# Altium schematic sheet Sensor_Box_GPRS.SchDoc
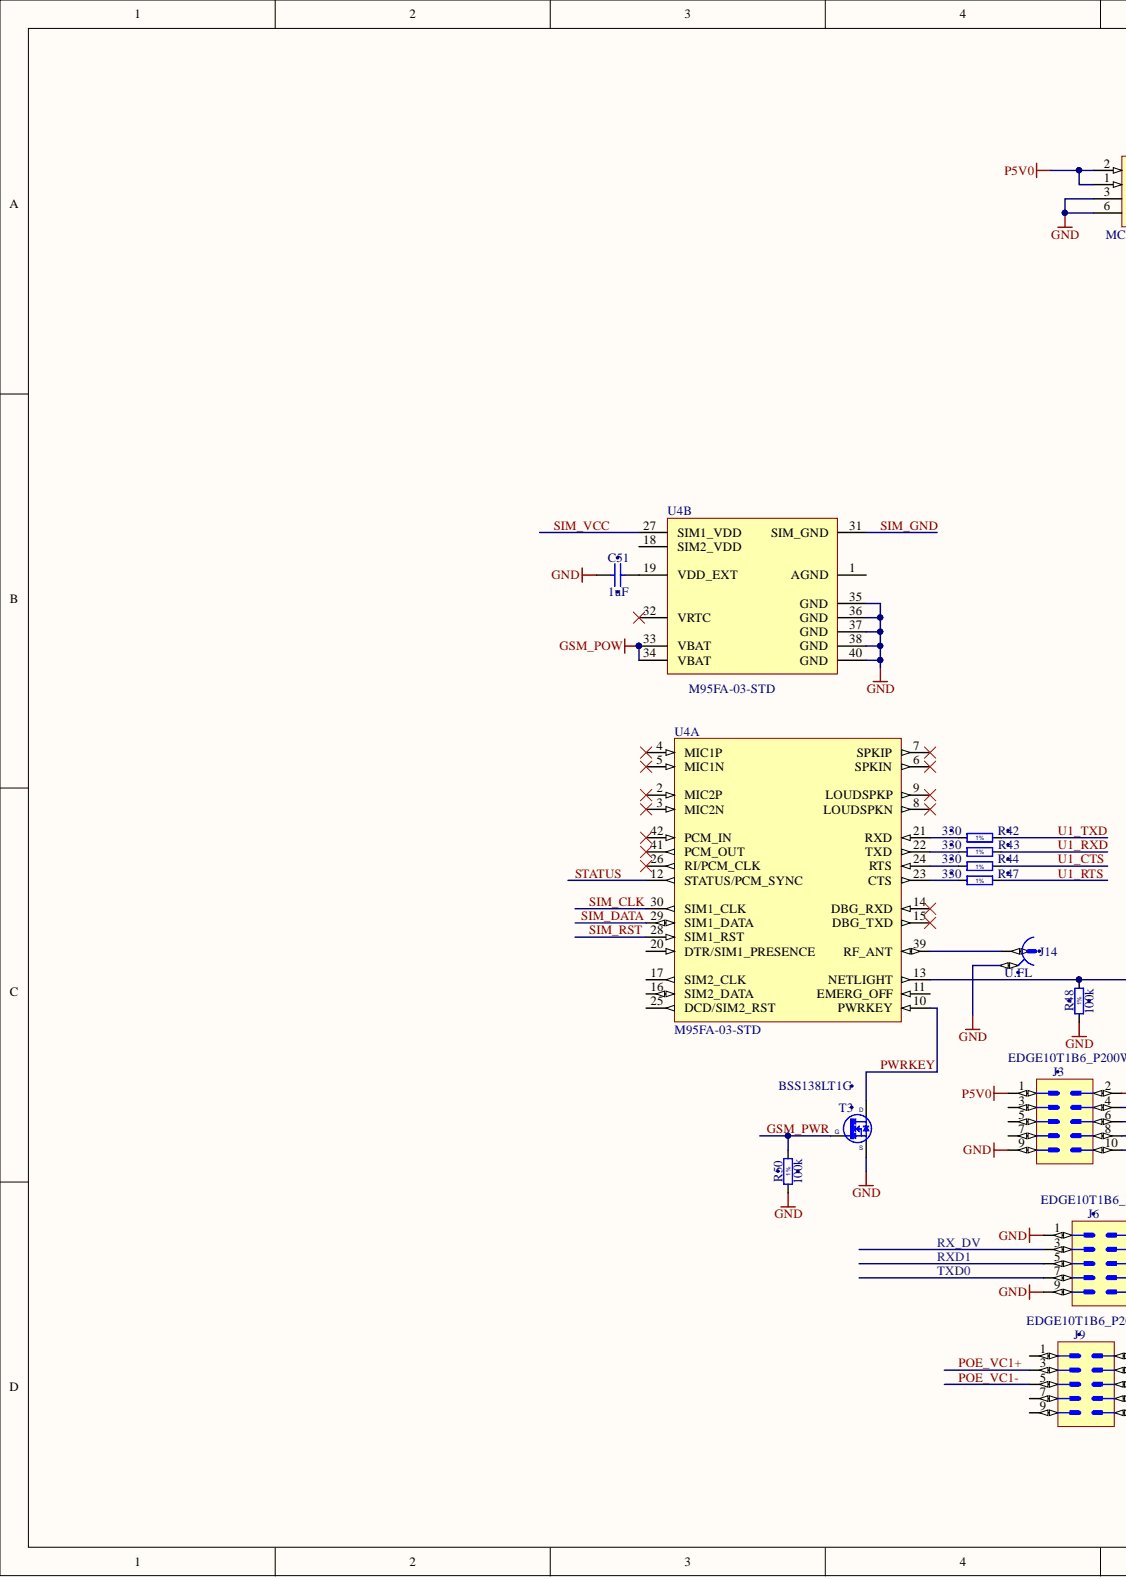
[diagram p0: Sensor_Box_GPRS.SchDoc, left]
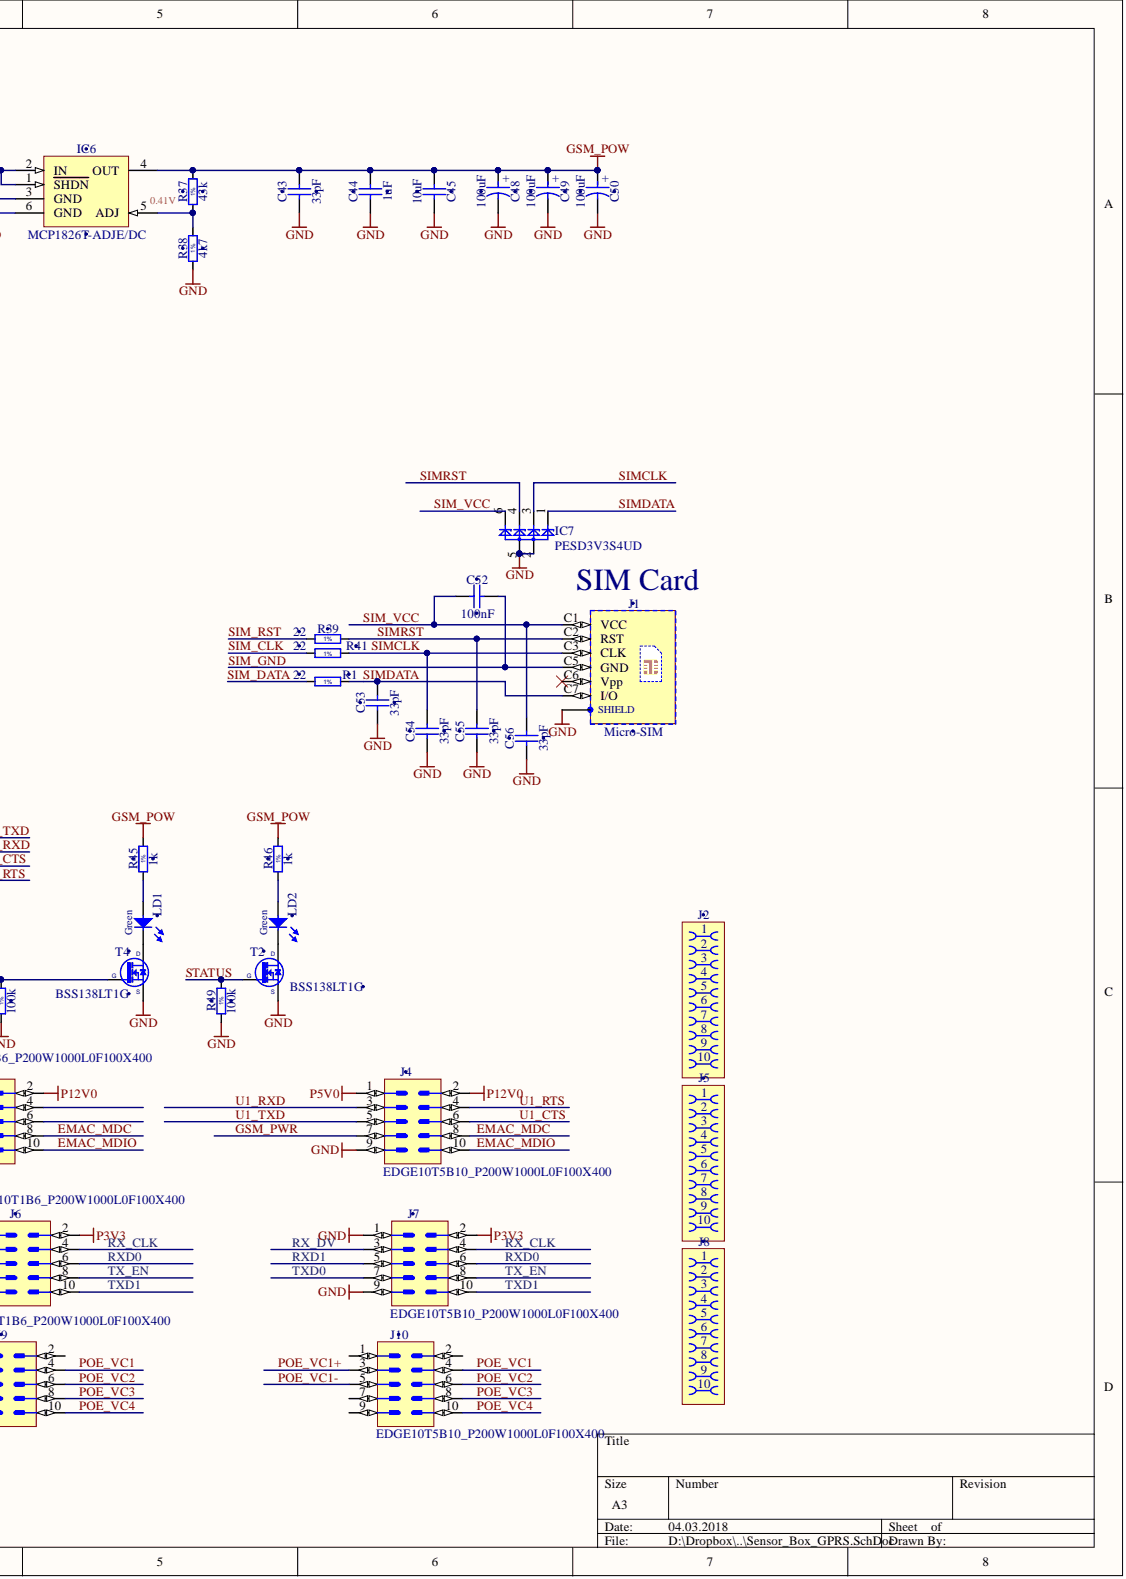
[diagram p1: Sensor_Box_GPRS.SchDoc, right]

## Parts
C43  33pF  [CAPC1005X55N]
C44  1uF  [CAPC1005X55N]
C45  10uF  [CAPC2012X135N]
C48  100uF  [CAPAE660X540N]
C49  100uF  [CAPAE660X540N]
C50  100uF  [CAPAE660X540N]
C51  1uF  [CAPC1005X55N]
C52  100nF  [CAPC1005X55N]
C53  33pF  [CAPC1005X55N]
C54  33pF  [CAPC1005X55N]
C55  33pF  [CAPC1005X55N]
C56  33pF  [CAPC1005X55N]
IC6  MCP1826T-ADJE/DC  [SOT127P700X180-6N]
IC7  PESD3V3S4UD  [SOT457]
J1  Micro-SIM  [MOLEX_78800-0001]
J2  Family  [AVX_00 9159 010 061 916]  (value not drawn)
J3  Family  [EDGE10T1B6_P200W1000L0F100X400]  (value not drawn)  (no BOM)
J4  Family  [EDGE10T5B10_P200W1000L0F100X400]  (value not drawn)  (no BOM)
J5  Family  [AVX_00 9159 010 061 916]  (value not drawn)
J6  Family  [EDGE10T1B6_P200W1000L0F100X400]  (value not drawn)  (no BOM)
J7  Family  [EDGE10T5B10_P200W1000L0F100X400]  (value not drawn)  (no BOM)
J8  Family  [AVX_00 9159 010 061 916]  (value not drawn)
J9  Family  [EDGE10T1B6_P200W1000L0F100X400]  (value not drawn)  (no BOM)
J10  Family  [EDGE10T5B10_P200W1000L0F100X400]  (value not drawn)  (no BOM)
J14  U.FL  [HIROSE_U.FL-R-SMT-1(10)]
LD1  Green  [LED_KINGBRIGHT_KPTR3216]
LD2  Green  [LED_KINGBRIGHT_KPTR3216]
R1  22  [RESC1005X40N]
R37  43k  [RESC1608X55N]
R38  4k7  [RESC1005X40N]
R39  22  [RESC1005X40N]
R41  22  [RESC1005X40N]
R42  330  [RESC1005X40N]
R43  330  [RESC1005X40N]
R44  330  [RESC1005X40N]
R45  1k  [RESC1005X40N]
R46  1k  [RESC1005X40N]
R47  330  [RESC1005X40N]
R48  100k  [RESC1005X40N]
R49  100k  [RESC1005X40N]
R50  100k  [RESC1005X40N]
T2  BSS138LT1G  [SOT95P240X110-3N]
T3  BSS138LT1G  [SOT95P240X110-3N]
T4  BSS138LT1G  [SOT95P240X110-3N]
U4  M95FA-03-STD  [QUEC-M95FA-03-STD_V]  (parts A,B)

## Nets
GND: C43.1 C44.1 C45.2 C48.2 C49.2 C50.2 C51.1 C53.1 C54.1 C55.1 C56.1 IC6.3(GND) IC6.6(GND) IC7.2 IC7.5 J1.SHIELD J3.9 J4.9 J6.1 J6.9 J7.1 J7.9 J14.2 R38.1 R48.1 R49.1 R50.1 T2.2 T3.2 T4.2 U4.35(GND) U4.36(GND) U4.37(GND) U4.38(GND) U4.40(GND)
GSM_POW: C43.2 C44.2 C45.1 C48.1 C49.1 C50.1 IC6.4(OUT) R37.2 R45.2 R46.2 U4.33(VBAT) U4.34(VBAT)
P3V3: J6.2 J7.2
P5V0: IC6.1(SHDN) IC6.2(IN) J3.1 J4.1
P12V0: J3.2 J4.2
EMAC_MDC: J3.8 J4.8
EMAC_MDIO: J3.10 J4.10
GSM_PWR: J4.7 R50.2 T3.1
POE_VC1: J9.4 J10.4
POE_VC1+: J9.3 J10.3
POE_VC1-: J9.5 J10.5
POE_VC2: J9.6 J10.6
POE_VC3: J9.8 J10.8
POE_VC4: J9.10 J10.10
PWRKEY: T3.3 U4.10(PWRKEY)
RX_CLK: J6.4 J7.4
RX_DV: J6.3 J7.3
RXD0: J6.6 J7.6
RXD1: J6.5 J7.5
SIM_CLK: R41.1 U4.30(SIM1_CLK)
SIM_DATA: R1.1 U4.29(SIM1_DATA)
SIM_GND: C52.2 J1.C5(GND) U4.31(SIM_GND)
SIM_RST: R39.1 U4.28(SIM1_RST)
SIM_VCC: C52.1 C56.2 IC7.6 J1.C1(VCC) U4.27(SIM1_VDD)
SIMCLK: C54.2 IC7.3 J1.C3(CLK) R41.2
SIMDATA: C53.2 IC7.1 J1.C7(I/O) R1.2
SIMRST: C55.2 IC7.4 J1.C2(RST) R39.2
STATUS: R49.2 T2.1 U4.12(STATUS/PCM_SYNC)
TX_EN: J6.8 J7.8
TXD0: J6.7 J7.7
TXD1: J6.10 J7.10
U1_CTS: J4.6 R44.2
U1_RTS: J4.4 R47.2
U1_RXD: J4.3 R43.2
U1_TXD: J4.5 R42.2
NetC51_2: C51.2 U4.19(VDD_EXT)
NetIC6_5: IC6.5(ADJ) R37.1 R38.2
NetJ14_1: J14.1 U4.39(RF_ANT)
NetLD1_1: LD1.1 T4.3
NetLD1_2: LD1.2 R45.1
NetLD2_1: LD2.1 T2.3
NetLD2_2: LD2.2 R46.1
NetR42_1: R42.1 U4.21(RXD)
NetR43_1: R43.1 U4.22(TXD)
NetR44_1: R44.1 U4.24(RTS)
NetR47_1: R47.1 U4.23(CTS)
NetR48_2: R48.2 T4.1 U4.13(NETLIGHT)

Not connected: J1 C6(Vpp); J3 3 4 5 6 7; J9 1 2 7 9; J10 1 2 7 9; U4 1(AGND) 2(MIC2P) 3(MIC2N) 4(MIC1P) 5(MIC1N) 6(SPKIN) 7(SPKIP) 8(LOUDSPKN) 9(LOUDSPKP) 11(EMERG_OFF) 14(DBG_RXD) 15(DBG_TXD) 16(SIM2_DATA) 17(SIM2_CLK) 18(SIM2_VDD) 20(DTR/SIM1_PRESENCE) 25(DCD/SIM2_RST) 26(RI/PCM_CLK) 32(VRTC) 41(PCM_OUT) 42(PCM_IN)

## Library data of the parts
C43 C53-C56 = CC0402_33PF_50V_5%_C0G: Non-polarised capacitor; Manufacturer: GENERIC; Manufacturer1 Part Number: C0402C330J5GACTU; Part Description: SMD Multilayer Chip Ceramic Capacitor; Database Table Name: Capacitors SMD; TC: C0G/NP0; Tolerance: ±5%; Voltage: 50V; Case: 0402; Component Kind: Standard; Component Type: Standard; CreateDate: 03.12.2007; HelpURL: \\cern.ch\dfs\Applications\Altium\Datasheets\CC0805_C0G_KEMET_(Commercial Grade).pdf; LatestRevisionDate: 22.04.2015; Library Name: Capacitors.DbLib; Manufacturer1 ComponentHeight: 0.55mm; Manufacturer1 Example: KEMET; Manufacturer Part Number: CC0402_33PF_50V_5%_C0G; Mounted: Yes; Part Number: CC0402_33PF_50V_5%_C0G; Pin Count: 2; Sense: No; SMD: Yes; Socket: No; Status: Preferred; Value: 33pF; Author: CERN DEM JLC
C44 C51 = CC0402_1UF_16V_10%_X5R: Non-polarised capacitor; Manufacturer: GENERIC; Manufacturer1 Part Number: C1005X5R1C105KT; Part Description: SMD Multilayer Chip Ceramic Capacitor; Database Table Name: Capacitors SMD; TC: X5R; Tolerance: ±10%; Voltage: 16V; Case: 0402; Component Kind: Standard; Component Type: Standard; CreateDate: 25.10.2012; HelpURL: \\cern.ch\dfs\Applications\Altium\Datasheets\CC0402_X5R_TDK_C.pdf; LatestRevisionDate: 25.10.2012; Library Name: Capacitors.DbLib; Manufacturer1 ComponentHeight: 0.55mm; Manufacturer1 Example: TDK; Manufacturer Part Number: CC0402_1UF_16V_10%_X5R; Mounted: Yes; Part Number: CC0402_1UF_16V_10%_X5R; Pin Count: 2; Sense: No; SMD: Yes; Socket: No; Status: Preferred; Value: 1uF; Author: CERN DEM JLC
C45 = CC0805_10UF_16V_10%_X5R: Non-polarised capacitor; Manufacturer: GENERIC; Manufacturer1 Part Number: C0805C106K4PAC; Part Description: SMD Multilayer Chip Ceramic Capacitor; Database Table Name: Capacitors SMD; TC: X5R; Tolerance: ±10%; Voltage: 16V; Case: 0805; Component Kind: Standard; Component Type: Standard; CreateDate: 03.12.2007; HelpURL: \\cern.ch\dfs\Applications\Altium\Datasheets\CC1206_X5R_KEMET.pdf; LatestRevisionDate: 03.12.2007; Library Name: Capacitors.DbLib; Manufacturer1 ComponentHeight: 1.4mm; Manufacturer1 Example: KEMET; Manufacturer Part Number: CC0805_10UF_16V_10%_X5R; Mounted: Yes; Part Number: CC0805_10UF_16V_10%_X5R; Pin Count: 2; Sense: No; SMD: Yes; Socket: No; Status: Preferred; Value: 10uF; Author: CERN DEM JLC
C48-C50 = CAE660-540_100UF_16V_20%: Polarised capacitor; Manufacturer: PANASONIC; Manufacturer Part Number: EEEFK1C101AP; Part Description: Aluminum Electrolytic SMT Capacitor; Database Table Name: Capacitors SMD; Tolerance: ±20%; Voltage: 16V; Case: D; ComponentHeight: 5.8mm; Component Kind: Standard; Component Type: Standard; CreateDate: 03.12.2007; HelpURL: \\cern.ch\dfs\Applications\Altium\Datasheets\CAE_PANASONIC_FK.pdf; LatestRevisionDate: 05.11.2014; Library Name: Capacitors.DbLib; Mounted: Yes; Part Number: CAE660-540_100UF_16V_20%; Pin Count: 2; Sense: No; SMD: Yes; Socket: No; Status: Not Recommended; Value: 100uF; Author: CERN DEM JLC
C52 = CC0402_100NF_16V_10%_X7R: Non-polarised capacitor; Manufacturer: GENERIC; Manufacturer1 Part Number: GRM155R71C104KA88D; Part Description: SMD Multilayer Chip Ceramic Capacitor; Database Table Name: Capacitors SMD; TC: X7R; Tolerance: ±10%; Voltage: 16V; Case: 0402; Component Kind: Standard; Component Type: Standard; CreateDate: 05.12.2013; HelpURL: \\cern.ch\dfs\Applications\Altium\Datasheets\CC0402_X7R_MURATA_GRM.pdf; LatestRevisionDate: 03.12.2007; Library Name: Capacitors.DbLib; Manufacturer1 ComponentHeight: 0.55mm; Manufacturer1 Example: MURATA; Manufacturer Part Number: CC0402_100NF_16V_10%_X7R; Mounted: Yes; Part Number: CC0402_100NF_16V_10%_X7R; Pin Count: 2; Sense: No; SMD: Yes; Socket: No; Status: Not Recommended; Value: 100nF; Author: CERN DEM JLC
IC6 = MCP1826T-ADJE/DC: 0.8V to 5.0V, 1A Low Voltage, Low Quiescent Current, Adjustable Positive LDO Regulator; Manufacturer: MICROCHIP TECHNOLOGY; Bonding: No; Database Table Name: Regulators; PressFit: No; Case: SOT223; ComponentHeight: 1.8mm; Component Kind: Standard; Component Type: Standard; CreateDate: 03.10.2012; Device: MCP1826T-ADJE/DC; HelpURL: \\cern.ch\dfs\Applications\Altium\Datasheets\MCP1826T-ADJE-DC.pdf; LatestRevisionDate: 03.10.2012; Library Name: ICs And Semiconductors.DbLib; Manufacturer Part Number: MCP1826T-ADJE/DC; Mounted: Yes; PackageDescription: SOT223, 6-Leads, Body 6.6x7.3mm (max), Pitch 1.27mm, IPC Medium Density; Part Description: 0.8V to 5.0V, 1A Low Voltage, Low Quiescent Current, Adjustable Positive LDO Regulator; Part Number: MCP1826T-ADJE/DC; Pin Count: 6; Sense: No; SMD: Yes; Socket: No; Author: CERN DEM JLC
IC7 = D_TVS_PESD3V3S4UD: 3.3V TVS; Component Kind: Standard; Library Name: LIBRARY_SCH.SCHLIB
J1 = MOLEX_78800-0001: 6 Contacts + Shield SIM Connector; Part Description: 1.50mm Height Hinged-style micro-SIM Card Socket Without Detect Switch, Surface Mount, 6 Circuits, Low-Halogen, Lead-Free; Database Table Name: MOLEX; PressFit: No; ComponentHeight: 1.6mm; Component Kind: Standard; Component Type: Standard; CreateDate: 30.01.2018; Family: Micro-SIM; HelpURL: \\cern.ch\dfs\Applications\Altium\Datasheets\MOLEX_78800-0001.pdf; LatestRevisionDate: 30.01.2018; Library Name: Connectors.DbLib; Manufacturer: MOLEX; Manufacturer Part Number: 78800-0001; Mounted: Yes; Part Number: MOLEX_78800-0001; Pin Count: 6; Sense: No; SMD: Yes; Socket: No; Status: None; Author: CERN DEM MR
J2 J5 J8 = AVX_00 9159 010 061 916: Open Ended Card Edge Connector, 9159 Series; Manufacturer: AVX; Part Description: 10 Contacts, 2mm Pitch, Open Ended Card Edge Connector, 9159 Series; Database Table Name: Miscellaneous; PressFit: No; ComponentHeight: 1.6mm; Component Kind: Standard; Component Type: Standard; CreateDate: 13.02.2018; HelpURL: \\cern.ch\dfs\Applications\Altium\Datasheets\AVX_00 9159 010 061 916.pdf; LatestRevisionDate: 13.02.2018; Library Name: Connectors.DbLib; Manufacturer Part Number: 00 9159 010 061 916; Mounted: Yes; Part Number: AVX_00 9159 010 061 916; Pin Count: 10; Sense: No; SMD: No; Socket: No; Status: None; Author: CERN DEM MR
J3 J6 J9 = EDGE10T1B6_P200W1000L0F100X400: PCB Edge Connector 10 (2x5); Part Description: 10 Contacts Board Edge Connector, Pitch 2mm, Length 0mm, Width 10mm, Finger Lenght 4mm, Finger Width 1mm; Database Table Name: Miscellaneous; Mounted: No; PressFit: No; ComponentHeight: 0mm; Component Type: Standard (No BOM); CreateDate: 14.02.2018; HelpURL: \\cern.ch\dfs\Applications\Altium\Datasheets\EDGE10T1B6_P200W1000L0F100X400.pdf; LatestRevisionDate: 14.02.2018; Library Name: Connectors.DbLib; Part Number: EDGE10T1B6_P200W1000L0F100X400; Pin Count: 10; Sense: No; SMD: No; Socket: No; Status: None; Author: CERN DEM MR
J4 J7 J10 = EDGE10T5B10_P200W1000L0F100X400: PCB Edge Connector 10 (2x5); Part Description: 10 Contacts Board Edge Connector, Pitch 2mm, Length 0mm, Width 10mm, Finger Lenght 4mm, Finger Width 1mm; Database Table Name: Miscellaneous; Mounted: No; PressFit: No; ComponentHeight: 0mm; Component Type: Standard (No BOM); CreateDate: 14.02.2018; HelpURL: \\cern.ch\dfs\Applications\Altium\Datasheets\EDGE10T5B10_P200W1000L0F100X400.pdf; LatestRevisionDate: 14.02.2018; Library Name: Connectors.DbLib; Part Number: EDGE10T5B10_P200W1000L0F100X400; Pin Count: 10; Sense: No; SMD: No; Socket: No; Status: None; Author: CERN DEM MR
J14 = HIROSE_U.FL-R-SMT-1(10): Manufacturer: HIROSE (HRS); Part Description: Up to 6GHz Ultra Small Surface Mount Male Coaxial Connectors U.FL Series; Database Table Name: Miscellaneous; PressFit: No; ComponentHeight: 1.25mm; Component Kind: Standard; Component Type: Standard; CreateDate: 24.03.2010; Family: U.FL; HelpURL: \\cern.ch\dfs\Applications\Altium\Datasheets\HIROSE_U.FL-R-SMT-1(10).pdf; LatestRevisionDate: 24.03.2010; Library Name: Connectors.DbLib; Manufacturer Part Number: U.FL-R-SMT-1(10); Mounted: Yes; Part Number: HIROSE_U.FL-R-SMT-1(10); Pin Count: 2; Sense: No; SMD: Yes; Socket: No; Status: None; Author: CERN DEM JLC
LD1 LD2 = LED_KINGBRIGHT_KPTR-3216CGCK: LED Green 1A 2C; Part Description: Reverse Mount LED 1.4x1.6mm Green; Bonding: No; Database Table Name: LEDs & Displays; PressFit: No; Color: Green; ComponentHeight: 0.35mm; Component Kind: Standard; Component Type: Standard; CreateDate: 15.02.2016; HelpURL: \\cern.ch\dfs\Applications\Altium\Datasheets\LED_KINGBRIGHT_KPTR-3216CGCK.pdf; LatestRevisionDate: 15.02.2016; Library Name: ICs And Semiconductors.DbLib; Manufacturer: KINGBRIGHT; Manufacturer Part Number: KPTR-3216CGCK; Mounted: Yes; PackageDescription: Reverse Mount LED 3216, Hole 2.1x2.3mm 0.35mm Height; Part Number: LED_KINGBRIGHT_KPTR-3216CGCK; Pin Count: 2; Sense: No; SMD: Yes; Socket: No; Author: CERN DEM MR
R1 R39 R41 = R0402_22R_1%_0.0625W_100PPM: Resistor - 1%; Manufacturer: GENERIC; Manufacturer1 Part Number: 232270672209L; Part Description: General Purpose Thick Film Chip Resistor; Database Table Name: Resistors SMD; PressFit: No; TC: ±100ppm/°C; Case: 0402; Component Kind: Standard; Component Type: Standard; CreateDate: 03.12.2007; HelpURL: \\cern.ch\dfs\Applications\Altium\Datasheets\R0402_Phycomp_RC0402.pdf; LatestRevisionDate: 17.10.2012; Library Name: Resistors.DbLib; Manufacturer1 ComponentHeight: 0.4mm; Manufacturer1 Example: YAGEO PHYCOMP; Manufacturer Part Number: R0402_22R_1%_0.0625W_100PPM; Mounted: Yes; Part Number: R0402_22R_1%_0.0625W_100PPM; Pin Count: 2; Power: 0.0625W; Sense: No; SMD: Yes; Socket: No; Status: Not Recommended; Tolerance: ±1%; Value: 22; Author: CERN DEM JLC
R37 = R0603_43K_1%_0.1W_100PPM: Resistor - 1%; Manufacturer: GENERIC; Manufacturer1 Part Number: NRC06F4302TRF; Part Description: General Purpose Thick Film Chip Resistor; Database Table Name: Resistors SMD; Power: 0.1W; PressFit: No; TC: ±100ppm/°C; Case: 0603; Component Kind: Standard; Component Type: Standard; CreateDate: 03.12.2007; HelpURL: \\cern.ch\dfs\Applications\Altium\Datasheets\R0603_NIC_NRC.pdf; LatestRevisionDate: 13.03.2008; Library Name: Resistors.DbLib; Manufacturer1 ComponentHeight: 0.55mm; Manufacturer1 Example: NIC COMPONENT; Manufacturer Part Number: R0603_43K_1%_0.1W_100PPM; Mounted: Yes; Part Number: R0603_43K_1%_0.1W_100PPM; Pin Count: 2; Sense: No; SMD: Yes; Socket: No; Status: Preferred; Tolerance: ±1%; Value: 43k; Author: CERN DEM JLC
R38 = R0402_4K7_1%_0.0625W_100PPM: Resistor - 1%; Manufacturer: GENERIC; Manufacturer1 Part Number: 232270674702L; Part Description: General Purpose Thick Film Chip Resistor; Database Table Name: Resistors SMD; PressFit: No; TC: ±100ppm/°C; Case: 0402; Component Kind: Standard; Component Type: Standard; CreateDate: 03.12.2007; HelpURL: \\cern.ch\dfs\Applications\Altium\Datasheets\R0402_Phycomp_RC0402.pdf; LatestRevisionDate: 17.10.2012; Library Name: Resistors.DbLib; Manufacturer1 ComponentHeight: 0.4mm; Manufacturer1 Example: YAGEO PHYCOMP; Manufacturer Part Number: R0402_4K7_1%_0.0625W_100PPM; Mounted: Yes; Part Number: R0402_4K7_1%_0.0625W_100PPM; Pin Count: 2; Power: 0.0625W; Sense: No; SMD: Yes; Socket: No; Status: Not Recommended; Tolerance: ±1%; Value: 4k7; Author: CERN DEM JLC
R42-R44 R47 = R0402_330R_1%_0.0625W_100PPM: Resistor - 1%; Manufacturer: GENERIC; Manufacturer1 Part Number: 232270673301L; Part Description: General Purpose Thick Film Chip Resistor; Database Table Name: Resistors SMD; PressFit: No; TC: ±100ppm/°C; Case: 0402; Component Kind: Standard; Component Type: Standard; CreateDate: 03.12.2007; HelpURL: \\cern.ch\dfs\Applications\Altium\Datasheets\R0402_Phycomp_RC0402.pdf; LatestRevisionDate: 17.10.2012; Library Name: Resistors.DbLib; Manufacturer1 ComponentHeight: 0.4mm; Manufacturer1 Example: YAGEO PHYCOMP; Manufacturer Part Number: R0402_330R_1%_0.0625W_100PPM; Mounted: Yes; Part Number: R0402_330R_1%_0.0625W_100PPM; Pin Count: 2; Power: 0.0625W; Sense: No; SMD: Yes; Socket: No; Status: Not Recommended; Tolerance: ±1%; Value: 330; Author: CERN DEM JLC
R45 R46 = R0402_1K_1%_0.0625W_100PPM: Resistor - 1%; Manufacturer: GENERIC; Manufacturer1 Part Number: 232270671002L; Part Description: General Purpose Thick Film Chip Resistor; Database Table Name: Resistors SMD; PressFit: No; TC: ±100ppm/°C; Case: 0402; Component Kind: Standard; Component Type: Standard; CreateDate: 03.12.2007; HelpURL: \\cern.ch\dfs\Applications\Altium\Datasheets\R0402_Phycomp_RC0402.pdf; LatestRevisionDate: 17.10.2012; Library Name: Resistors.DbLib; Manufacturer1 ComponentHeight: 0.4mm; Manufacturer1 Example: YAGEO PHYCOMP; Manufacturer Part Number: R0402_1K_1%_0.0625W_100PPM; Mounted: Yes; Part Number: R0402_1K_1%_0.0625W_100PPM; Pin Count: 2; Power: 0.0625W; Sense: No; SMD: Yes; Socket: No; Status: Not Recommended; Tolerance: ±1%; Value: 1k; Author: CERN DEM JLC
R48-R50 = R0402_100K_1%_0.0625W_100PPM: Resistor - 1%; Manufacturer: GENERIC; Manufacturer1 Part Number: 232270671004L; Part Description: General Purpose Thick Film Chip Resistor; Database Table Name: Resistors SMD; PressFit: No; TC: ±100ppm/°C; Case: 0402; Component Kind: Standard; Component Type: Standard; CreateDate: 03.12.2007; HelpURL: \\cern.ch\dfs\Applications\Altium\Datasheets\R0402_Phycomp_RC0402.pdf; LatestRevisionDate: 17.10.2012; Library Name: Resistors.DbLib; Manufacturer1 ComponentHeight: 0.4mm; Manufacturer1 Example: YAGEO PHYCOMP; Manufacturer Part Number: R0402_100K_1%_0.0625W_100PPM; Mounted: Yes; Part Number: R0402_100K_1%_0.0625W_100PPM; Pin Count: 2; Power: 0.0625W; Sense: No; SMD: Yes; Socket: No; Status: Not Recommended; Tolerance: ±1%; Value: 100k; Author: CERN DEM JLC
T2-T4 = BSS138LT1G: N-CHANNEL MOSFET WITH DIODE; Manufacturer: ON SEMICONDUCTOR; Part Description: 50V 200mA N-Channel Enhancement Mode Field-Effect Transistor; Bonding: No; Database Table Name: Transistors; PressFit: No; Case: SOT23-3; ComponentHeight: 1.11mm; Component Kind: Standard; Component Type: Standard; CreateDate: 23.03.2009; Device: BSS138LT1G; HelpURL: \\cern.ch\dfs\Applications\Altium\Datasheets\BSS138LT1G.pdf; LatestRevisionDate: 23.03.2009; Library Name: ICs And Semiconductors.DbLib; Manufacturer Part Number: BSS138LT1G; Mounted: Yes; PackageDescription: SOT23 3-Leads, Pitch 0.95mm - Body 1.4x3mm, IPC Medium Density; Part Number: BSS138LT1G; Pin Count: 3; Sense: No; SMD: Yes; Socket: No; Author: CERN DEM JLC
U4 = CMP-2000-06650-1: Quad-Band GSM / GPRS Module, 850 / 900 / 1800 / 1900 MHz, 3.3 to 4.6 V, -40 to 85 degC, 42-Pin SMD, RoHS, Tape and Reel; Manufacturer: QUECTELWIRELESSSOLUTIONS; Category: RFModulesMisc; Component Kind: Standard; Datasheet URL: https://www.codico.com/shop/media/datasheets/Quectel_M95_Hardware_Design_V3.1.pdf; Datasheet Version: Rev. 3.1, 11/2014; Library Name: Altium Content Vault; Manufacturer URL: http://www.quectel.com/; Mounting Technology: SurfaceMount; Operating Temperature: -40to85°C; Package: 42LCC; Package Description: 42-Pin Sourface Mount Device, Body 23.6 x 19.9 mm; Package Reference: M95FA-03-STD
